# Altium schematic sheet main.SchDoc(main)
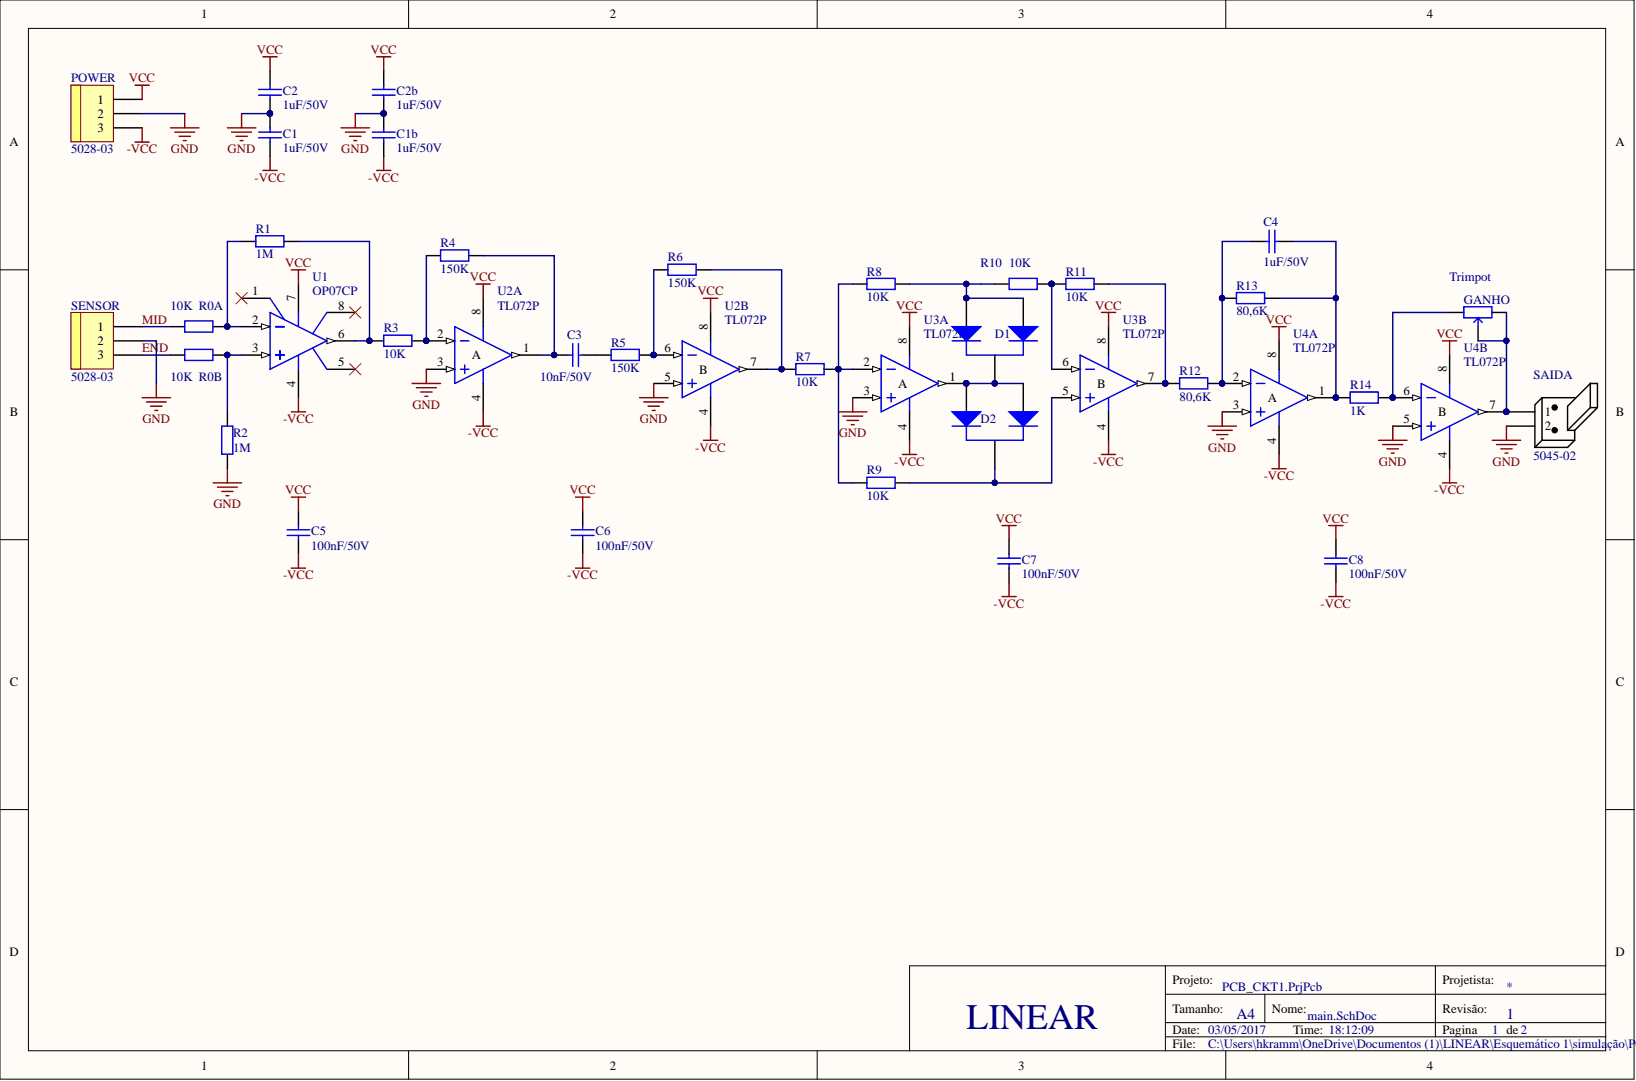
[diagram p0: main.SchDoc]

## Parts
C1  value 1uF/50V  [CAP 0805]  (hidden comment: Cap.Cer. 1uF/50V SMD)
C1b  value 1uF/50V  [CAP 0805]  (hidden comment: Cap.Cer. 1uF/50V SMD)
C2  value 1uF/50V  [CAP 0805]  (hidden comment: Cap.Cer. 1uF/50V SMD)
C2b  value 1uF/50V  [CAP 0805]  (hidden comment: Cap.Cer. 1uF/50V SMD)
C3  value 10nF/50V  [CAP 0805]  (hidden comment: Cap.Cer. 10nF/50V SMD)
C4  value 1uF/50V  [CAP 0805]  (hidden comment: Cap.Cer. 1uF/50V SMD)
C5  value 100nF/50V  [CAP 0805]  (hidden comment: Cap.Cer. 100nF/50V SMD)
C6  value 100nF/50V  [CAP 0805]  (hidden comment: Cap.Cer. 100nF/50V SMD)
C7  value 100nF/50V  [CAP 0805]  (hidden comment: Cap.Cer. 100nF/50V SMD)
C8  value 100nF/50V  [CAP 0805]  (hidden comment: Cap.Cer. 100nF/50V SMD)
D1  Diode BAS70-05 SMD  [SOT23_M]  (value not drawn)
D2  Diode BAS70-05 SMD  [SOT23_M]  (value not drawn)
GANHO  Trimpot  [Trimpot - proto]
POWER  5028-03  [5028-03]
R0A  value 10K  [RES 0805]  (hidden comment: Res 10K SMD)
R0B  value 10K  [RES 0805]  (hidden comment: Res 10K SMD)
R1  value 1M  [RES 0805]  (hidden comment: Res 1M SMD)
R2  value 1M  [RES 0805]  (hidden comment: Res 1M SMD)
R3  value 10K  [RES 0805]  (hidden comment: Res 10K SMD)
R4  value 150K  [RES 0805]  (hidden comment: Res 150K SMD)
R5  value 150K  [RES 0805]  (hidden comment: Res 150K SMD)
R6  value 150K  [RES 0805]  (hidden comment: Res 150K SMD)
R7  value 10K  [RES 0805]  (hidden comment: Res 10K SMD)
R8  value 10K  [RES 0805]  (hidden comment: Res 10K SMD)
R9  value 10K  [RES 0805]  (hidden comment: Res 10K SMD)
R10  value 10K  [RES 0805]  (hidden comment: Res 10K SMD)
R11  value 10K  [RES 0805]  (hidden comment: Res 10K SMD)
R12  value 80,6K  [RES 0805]  (hidden comment: Res 80,6K SMD)
R13  value 80,6K  [RES 0805]  (hidden comment: Res 80,6K SMD)
R14  value 1K  [RES 0805]  (hidden comment: Res 1K SMD)
SAIDA  5045-02  [5045-02]
SENSOR  5028-03  [5028-03]
U1  OP07CP  [PDIP300-P8 - proto]
U2  TL072P  [PDIP300-P8 - proto]  (parts A,B)
U3  TL072P  [PDIP300-P8 - proto]  (parts A,B)
U4  TL072P  [PDIP300-P8 - proto]  (parts A,B)

## Nets
-VCC: C1.1 C1b.1 C5.1 C6.1 C7.1 C8.1 POWER.3 U1.4 U2.4 U3.4 U4.4
GND: C1.2 C1b.2 C2.1 C2b.1 POWER.2 R2.1 SAIDA.2 SENSOR.2 U2.3 U2.5 U3.3 U4.3 U4.5
VCC: C2.2 C2b.2 C5.2 C6.2 C7.2 C8.2 POWER.1 U1.7 U2.8 U3.8 U4.8
END: R0B.1 SENSOR.3
MID: R0A.1 SENSOR.1
NetC3_1: C3.1 R4.2 U2.1
NetC3_2: C3.2 R5.1
NetC4_1: C4.1 R12.2 R13.1 U4.2
NetC4_2: C4.2 R13.2 R14.1 U4.1
NetD1_1: D1.1 D1.2 R8.1 R10.2
NetD1_3: D1.3 D2.1 D2.2 U3.1
NetD2_3: D2.3 R9.1 U3.5
NetGANHO_1: GANHO.1 GANHO.2 SAIDA.1 U4.7
NetGANHO_3: GANHO.3 R14.2 U4.6
NetR0A_2: R0A.2 R1.1 U1.2
NetR0B_2: R0B.2 R2.2 U1.3
NetR1_2: R1.2 R3.2 U1.6
NetR3_1: R3.1 R4.1 U2.2
NetR5_2: R5.2 R6.1 U2.6
NetR6_2: R6.2 R7.2 U2.7
NetR7_1: R7.1 R8.2 R9.2 U3.2
NetR10_1: R10.1 R11.2 U3.6
NetR11_1: R11.1 R12.1 U3.7

Not connected: U1 1 5 8

## Library data of the parts
C1 C1b C2 C2b C4 = Cap.Cer. 1uF/50V SMD: Capacitor Cerâmico; Código: 7416; Almox.Gaia: SMD CAPACITOR CERAMICO 1uF/50V 0805; Supplier Part Number 2: 06R3936; Supplier Part Number 1: CL21F105ZBFNNNF-ND; Supplier 2: Newark; Supplier 1: Digi-Key; Simulation: CAP; Signal Integrity: Cap; Published: 8-Jun-2000; PackageReference: RAD-0.3; Library Name: almox Gaia.SchLib; LatestRevisionNote: Re-released for DXP Platform.; LatestRevisionDate: 22-Mar-2011; Component Kind: Standard; Publisher: Altium Limited
C3 = Cap.Cer. 10nF/50V SMD: Capacitor Cerâmico; Código: 7022; Almox.Gaia: SMD CAPACITOR CERAMICO 10nF/50V 0805; Value: 10nF/50V; Supplier Part Number 2: 96M1408; Supplier Part Number 1: 311-1136-2-ND; Supplier 2: Newark; Supplier 1: Digi-Key; Simulation: CAP; Signal Integrity: Cap; Published: 8-Jun-2000; PackageReference: RAD-0.3; Library Name: almox Gaia.SchLib; LatestRevisionNote: Re-released for DXP Platform.; LatestRevisionDate: 22-Mar-2011; Component Kind: Standard; Publisher: Altium Limited
C5-C8 = Cap.Cer. 100nF/50V SMD: Capacitor Cerâmico; Código: 7021; Almox.Gaia: SMD CAPACITOR CERAMICO 100nF/50V 0805; Supplier Part Number 2: 58Y7818; Supplier Part Number 1: 1276-1007-2-ND; Supplier 2: Newark; Supplier 1: Digi-Key; Simulation: CAP; Signal Integrity: Cap; Published: 8-Jun-2000; PackageReference: RAD-0.3; Library Name: almox Gaia.SchLib; LatestRevisionNote: Re-released for DXP Platform.; LatestRevisionDate: 22-Mar-2011; Component Kind: Standard; Publisher: Altium Limited
D1 D2 = Diode BAS70-05 SMD: Surface Mount Schottky Barrier Diode; Código: 7343; Supplier Part Number 2: 75R4957; Supplier Part Number 1: BAS70-05,235-ND; Supplier 2: Newark; Supplier 1: Digi-Key; Simulation: 1N4007; Signal Integrity: 1N4007; Published: 8-Jun-2000; PCB3D: diode; PackageVersion: Aug-1999; PackageReference: DO-41; LatestRevisionNote: Re-released for DXP Platform.; LatestRevisionDate: 22-Mar-2011; DatasheetVersion: 1998; ComponentLink2URL: http://www.fairchildsemi.com/ds/1N%2F1N4001.pdf; ComponentLink2Description: Datasheet; ComponentLink1URL: http://www.fairchildsemi.com/; ComponentLink1Description: Manufacturer Link; Component Kind: Standard; Code_JEDEC: DO-204-AL; Almox.Gaia: Diode BAS70-05 SMD; Publisher: Altium Limited; Library Name: almox Gaia.SchLib
GANHO = Trimpot: Variable Resistor; Sim Note: Enter the Resistance value and the set point into parameter fields.; Set Position: 0.5; Código: 7273; Almox.Gaia: TRIMPOT 10K VERTIVAL; Simulation: POT; Signal Integrity: Res Tap; Published: 24-Mar-1999; PackageReference: VR3; Library Name: almox Gaia.SchLib; LatestRevisionNote: Re-released for DXP Platform.; LatestRevisionDate: 17-Jul-2002; Component Kind: Standard; Publisher: Altium Limited
POWER SENSOR = CONECTOR 5028-03: CONECTOR MOLEX KK 5028 180° 3,96mm  3 VIAS; Código: 000583; Supplier Part Number 2: 81C8363; Supplier Part Number 1: WM18824-ND; Supplier 2: Newark; Supplier 1: Digi-Key; Signal Integrity: Connector; LatestRevisionNote: Re-released for DXP Platform.; LatestRevisionDate: 22-Mar-2011; Component Kind: Standard; Almox.Gaia: CONECTOR 5028-03; Publisher: Altium Limited; Library Name: almox Gaia.SchLib
R0A R0B R3 R7-R11 = Res 10K SMD: Resistor; Código: 004905; Almox.Gaia: SMD RESISTOR   10K 0805; Supplier Part Number 2: 65J1362; Supplier Part Number 1: RMCF0805JT10K0TR-ND; Supplier 2: Newark; Supplier 1: Digi-Key; Simulation: RESISTOR; Signal Integrity: Res; Published: 8-Jun-2000; PackageReference: AXIAL-0.4; Library Name: almox Gaia.SchLib; LatestRevisionNote: Re-released for DXP Platform.; LatestRevisionDate: 22-Mar-2011; Component Kind: Standard; Publisher: Altium Limited
R1 R2 = Res 2M SMD: Resistor; Código: 005147; Almox.Gaia: SMD RESISTOR    2M 0805; Supplier Part Number 2: 59M6868; Supplier Part Number 1: P2.0MACT-ND; Supplier 2: Newark; Supplier 1: Digi-Key; Simulation: RESISTOR; Signal Integrity: Res; Published: 8-Jun-2000; PackageReference: AXIAL-0.4; Library Name: almox Gaia.SchLib; LatestRevisionNote: Re-released for DXP Platform.; LatestRevisionDate: 22-Mar-2011; Component Kind: Standard; Publisher: Altium Limited
R4-R6 = Res 15K SMD: Resistor; Código: 005143; Almox.Gaia: SMD RESISTOR   15K 0805; Supplier Part Number 2: 59M6856; Supplier Part Number 1: RMCF0805JT15K0TR-ND; Supplier 2: Newark; Supplier 1: Digi-Key; Simulation: RESISTOR; Signal Integrity: Res; Published: 8-Jun-2000; PackageReference: AXIAL-0.4; Library Name: almox Gaia.SchLib; LatestRevisionNote: Re-released for DXP Platform.; LatestRevisionDate: 22-Mar-2011; Component Kind: Standard; Publisher: Altium Limited
R12 R13 = Res 68K SMD: Resistor; Código: 005139; Almox.Gaia: SMD RESISTOR 68K 0805; Supplier Part Number 2: 58K4893; Supplier Part Number 1: RMCF0805JT68K0TR-ND; Supplier 2: Newark; Supplier 1: Digi-Key; Simulation: RESISTOR; Signal Integrity: Res; Published: 8-Jun-2000; PackageReference: AXIAL-0.4; Library Name: almox Gaia.SchLib; LatestRevisionNote: Re-released for DXP Platform.; LatestRevisionDate: 22-Mar-2011; Component Kind: Standard; Publisher: Altium Limited
R14 = Res 1K SMD: Resistor; Código: 004903; Almox.Gaia: SMD RESISTOR    1K 0805; Supplier Part Number 2: 65J1337; Supplier Part Number 1: P1.00KCCT-ND; Supplier 2: Newark; Supplier 1: Digi-Key; Simulation: RESISTOR; Signal Integrity: Res; Published: 8-Jun-2000; PackageReference: AXIAL-0.4; Library Name: almox Gaia.SchLib; LatestRevisionNote: Re-released for DXP Platform.; LatestRevisionDate: 22-Mar-2011; Component Kind: Standard; Publisher: Altium Limited
SAIDA = CONECTOR 5045-02: CONECTOR MOLEX KK 5045 180° 2,54mm  3 VIAS; Código: 000584; Signal Integrity: Connector; LatestRevisionNote: Re-released for DXP Platform.; LatestRevisionDate: 22-Mar-2011; Component Kind: Standard; Almox.Gaia: CONECTOR 5045-02; Publisher: Altium Limited; Library Name: almox Gaia.SchLib
U1 = OP07CP: Ultra-Low Offset Voltage Operational Amplifier; Note: Pin 5 is not connected.; Published: 8-Jun-2000; PCB3D: N-8A; PackageReference: N-8_A; PackageDescription: DIP; 8 Leads; Row Spacing 7.62 mm; Pitch 2.54 mm; Library Name: AD Operational Amplifier.IntLib; LatestRevisionNote: Stylized 3D Model Added.; LatestRevisionDate: 04-Mar-2005; DatasheetVersion: 1992; ComponentLink2URL: http://www.analog.com/UploadedFiles/Data_Sheets/39161232478959OP07_c.pdf; ComponentLink2Description: Datasheet; ComponentLink1URL: http://www.analog.com/en/prod/0,,759_786_OP07%2C00.html; ComponentLink1Description: Manufacturer Link; Component Kind: Standard; Code_JEDEC: MO-001-AN; Publisher: Altium Limited
U2-U4 = TL072P: Low-Noise JFET-Input Operational Amplifier; Simulation: TL072; Published: 16-Jan-2001; PCB3D: P008; PackageVersion: Jun-1999; PackageReference: P008; PackageDescription: DIP; 8 Leads; Row Spacing 7.62 mm; Pitch 2.54 mm; Library Name: TI Operational Amplifier.IntLib; LatestRevisionNote: Stylized 3D Model Added.; LatestRevisionDate: 04-Mar-2005; DatasheetVersion: Aug-1996; ComponentLink2URL: http://www-s.ti.com/sc/ds/tl072.pdf; ComponentLink2Description: Datasheet; ComponentLink1URL: http://www.ti.com/; ComponentLink1Description: Manufacturer Link; Component Kind: Standard; Code_JEDEC: MO-001-AN; Publisher: Altium Limited
